# Altium schematic sheet MCU.SchDoc
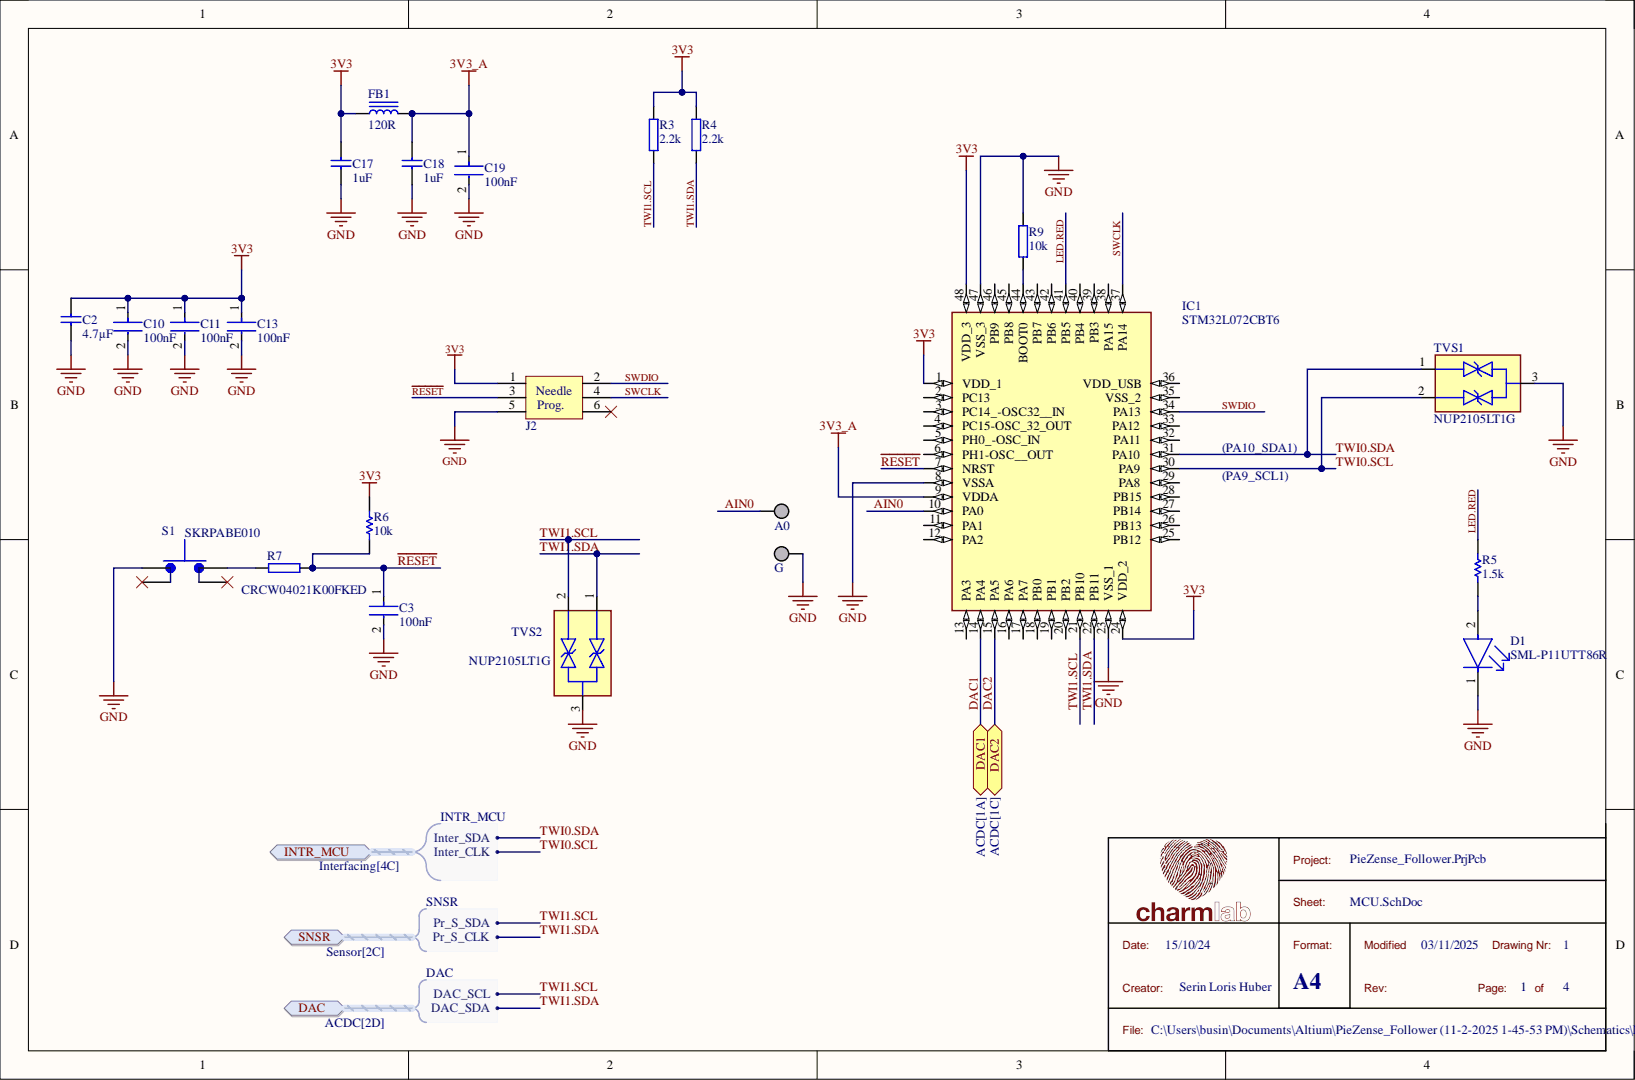
[diagram p0: MCU.SchDoc]

## Parts
A0  Test point  [TEST_POINT]  (value not drawn)  (no BOM)
C2  4.7µF  [CAP0603]
C3  100nF  [FP-GRM155-0_05-MFG]
C10  100nF  [FP-GRM155-0_05-MFG]
C11  100nF  [FP-GRM155-0_05-MFG]
C13  100nF  [FP-GRM155-0_05-MFG]
C17  1uF  [CAP0402]
C18  1uF  [CAP0402]
C19  100nF  [FP-GRM155-0_05-MFG]
D1  SML-P11UTT86R  [FP-SML-P1-MFG]
FB1  120R  [FB0402]
G  Test point  [TEST_POINT]  (value not drawn)  (no BOM)
IC1  STM32L072CBT6  [QFP50P900X900X160-48N]
J2  TC2030-MCP-NL  [6-Pin_Needle_Programmer]  (value not drawn)  (no BOM)
R3  2.2k  [RES0402]
R4  2.2k  [RES0402]
R5  1.5k  [RESC1005X40X25LL05T10]
R6  10k  [RESC1005X40X25ML05T10]
R7  CRCW04021K00FKED  [RES0402]
R9  10k  [RES0402]
S1  SKRPABE010  [SW_SKRPABE010]
TVS1  NUP2105LT1G  [SOT95P237]
TVS2  NUP2105LT1G  [SOT95P237]

## Ports
DAC, DAC1, DAC2, DAC.DAC_SCL, DAC.DAC_SDA, INTR_MCU, INTR_MCU.Inter_CLK, INTR_MCU.Inter_SDA, SNSR, SNSR.Pr_S_CLK, SNSR.Pr_S_SDA

## Nets
3V3: C2.1 C10.1 C11.1 C13.1 C17.2 FB1.1 IC1.1(VDD_1) IC1.24(VDD_2) IC1.48(VDD_3) J2.1 R3.2 R4.2 R6.2
3V3_A: C18.2 C19.1 FB1.2 IC1.9(VDDA)
GND: C2.2 C3.2 C10.2 C11.2 C13.2 C17.1 C18.1 C19.2 D1.1 G.1 IC1.8(VSSA) IC1.23(VSS_1) IC1.47(VSS_3) J2.5 R9.2 S1.1 TVS1.3 TVS2.3
AIN0: A0.1 IC1.10(PA0)
DAC1: IC1.14(PA4) ; port DAC1
DAC2: IC1.15(PA5) ; port DAC2
LED.RED: IC1.41(PB5) R5.2
~{RESET}: C3.1 IC1.7(NRST) J2.3 R6.1 R7.2
SWCLK: IC1.37(PA14) J2.4(Needle)
SWDIO: IC1.34(PA13) J2.2
TWI0.SCL: IC1.30(PA9) TVS1.2 ; port INTR_MCU
TWI0.SDA: IC1.31(PA10) TVS1.1 ; port INTR_MCU
TWI1.SCL: IC1.21(PB10) R3.1 TVS2.2 ; port DAC, port SNSR
TWI1.SDA: IC1.22(PB11) R4.1 TVS2.1 ; port DAC, port SNSR
NetD1_2: D1.2 R5.1
NetIC1_44: IC1.44(BOOT0) R9.1
NetR7_1: R7.1 S1.3

Not connected: IC1 2(PC13) 3(PC14_-OSC32__IN) 4(PC15-OSC_32_OUT) 5(PH0_-OSC_IN) 6(PH1-OSC__OUT) 11(PA1) 12(PA2) 13(PA3) 16(PA6) 17(PA7) 18(PB0) 19(PB1) 20(PB2) 25(PB12) 26(PB13) 27(PB14) 28(PB15) 29(PA8) 32(PA11) 33(PA12) 35(VSS_2) 36(VDD_USB) 38(PA15) 39(PB3) 40(PB4) 42(PB6) 43(PB7) 45(PB8) 46(PB9); J2 6; S1 2 4

## Library data of the parts
C2 = GRM188R6YA475KE15: CAP CER 4.7uF 35V X5R 0603; Manufacturer: Murata Electronics; Manufacturer Part Number: GRM188R6YA475KE15D; Part Number: GRT033C80J224KE01D; Capacitor Type: Ceramic; Multilayer: Yes; Negative Tolerance: 10%; Temperature Coefficient: X6S; Tolerance: ±10%; Voltage - Rated: 6.3V; Applications: Automotive; Capacitance: 4.7µF; Category: Ceramic Capacitors; Component Kind: Standard; ComponentLink1Description: Datasheet; ComponentLink1URL: https://search.murata.co.jp/Ceramy/image/img/A01X/G101/ENG/GRT033C80J224KE01-01.pdf; ComponentLink2Description: DigiKey Link; ComponentLink2URL: http://digikey.com/product-detail/en/murata-electronics/GRT033C80J224KE01D/490-12230-1-ND/5416931; ComponentLink3Description: https://datasheet.ciiva.com:443/pdfs/VipMasterIC/IC/MURE/MURE-S-A0004349797/MURE-S-A0004352734-1.pdf?src-supplier=IHS+Markit; ComponentLink3URL: https://datasheet.ciiva.com:443/pdfs/VipMasterIC/IC/MURE/MURE-S-A0004349797/MURE-S-A0004352734-1.pdf?src-supplier=IHS+Markit; ComponentLink4Description: https://datasheet.ciiva.com/46444/1769893-46444984.pdf?src-supplier=Element14; ComponentLink4URL: https://datasheet.ciiva.com/46444/1769893-46444984.pdf?src-supplier=Element14; ComponentLink5Description: https://datasheet.ciiva.com/46444/1769893-46444985.pdf?src-supplier=Element14; ComponentLink5URL: https://datasheet.ciiva.com/46444/1769893-46444985.pdf?src-supplier=Element14; ComponentLink6Description: https://datasheet.ciiva.com/14906/partnumbering-e-01-14906427.pdf?src-supplier=Digi-Key; ComponentLink6URL: https://datasheet.ciiva.com/14906/partnumbering-e-01-14906427.pdf?src-supplier=Digi-Key; ComponentLink7Description: https://datasheet.ciiva.com/14906/partnumbering-e-01-14906426.pdf?src-supplier=Digi-Key; ComponentLink7URL: https://datasheet.ciiva.com/14906/partnumbering-e-01-14906426.pdf?src-supplier=Digi-Key; ComponentLink8Description: https://datasheet.ciiva.com/14906/partnumbering-e-01-14906428.pdf?src-supplier=Digi-Key; ComponentLink8URL: https://datasheet.ciiva.com/14906/partnumbering-e-01-14906428.pdf?src-supplier=Digi-Key; Device Package: 0201 (0603 Metric); Dielectric Material: Ceramic; Features: Derating Recommended; Height: 0.8mm; JESD-609 Code: e3; LastUpdated: 02/09/2020 00:58:08; Length: 1.6mm; Lifecycle Status: Active; Max Operating Temperature: 85°C; Min Operating Temperature: -55°C; Minimum Order: 1; Mount: Surface Mount; Mounting Type: Surface Mount, MLCC; Number of Pins: 2; Operating Temperature: -55°C ~ 105°C; Package / Case: 0201 (0603 Metric); Package Shape: Rectangular; Package Style: SMTMeter; Packaging: TapeAndReel; Part Status: Active; PartId: 1513; Positive Tolerance: 10%; Price: 0.01; Pricing 1: 25=0.48200, 250=0.45200, 500=0.42400, 1000=0.40000, 2500=0.36100 (USD); Rated DC Voltage (URdc): 35V; Ratings: AEC-Q200; REACH SVHC: Yes; RoHS: Yes; RoHS Compliant: Yes; Series: GRT; Size / Dimension: 0.024" L x 0.012" W (0.60mm x 0.30mm); Size Code: 0603; Stock 1: 3100; Supplier 1: RSComponents; Supplier 2: Mouser; Supplier 3: Digikey; Supplier 4: Digikey; Supplier 5: Digikey; Supplier Part Number 1: 8151351; Supplier Part Number 2: 81-GRM188R6YA475KE5D; Supplier Part Number 3: 490-7205-1-ND; Supplier Part Number 4: 490-7205-6-ND; Supplier Part Number 5: 490-7205-2-ND; Temperature Characteristics Code: X5R; Terminal Finish: Nickel; Termination: Wraparound; Thickness (Max): 0.013" (0.33mm); Value: 4.7µF; Width: 0.8mm; Library Name: schuluka.SCHLIB
C3 C10 C11 C13 C19 = CMP-2008-04108-2: Chip Multilayer Ceramic Capacitors for General Purpose, 0402, 0.10uF, X5R, 15%, 10%, 50V; Capacitance: 100nF; Case Code (Imperial): 0402; Case Code (Metric): 1005; Case/Package: 0402; Component Kind: Standard; Depth: 0.5mm; Dielectric: X5R; Height: 0.5mm; Lead Free: Lead Free; Length: 1mm; Library Name: Altium Content Vault; Max Operating Temperature: 85°C; Min Operating Temperature: -55°C; Mount: Surface Mount; Packaging: Tape and Reel; REACH SVHC: No SVHC; Thickness: 0.022inch; Tolerance: 10%; Voltage: 50V; Voltage Rating: 50V; Voltage Rating (DC): 50V; Width: 0.02inch
C17 C18 = GRM155R61E105KA12J: CAP CER 1uF 25V X5R 0402; Manufacturer: Murata; Manufacturer Part Number: GRM033R61A105ME15D; Capacitor Type: Ceramic; Multilayer: Yes; Negative Tolerance: 10%; Tolerance: 20%; Voltage Rating: 10V; Capacitance: 1uF; Case Code (Imperial): 0201; Case Code (Metric): 0603; Case/Package: 0201; Category: Ceramic Capacitors; Component Kind: Standard; ComponentLink1Description: http://search.murata.co.jp/Ceramy/image/img/A01X/G101/ENG/GRM033R61A105ME15-01.pdf?src-supplier=Digi-Key; ComponentLink1URL: http://search.murata.co.jp/Ceramy/image/img/A01X/G101/ENG/GRM033R61A105ME15-01.pdf?src-supplier=Digi-Key; ComponentLink2Description: https://datasheet.ciiva.com:443/pdfs/VipMasterIC/IC/MURE/MURE-S-A0004362469/MURE-S-A0004364524-1.pdf?src-supplier=IHS+Markit; ComponentLink2URL: https://datasheet.ciiva.com:443/pdfs/VipMasterIC/IC/MURE/MURE-S-A0004362469/MURE-S-A0004364524-1.pdf?src-supplier=IHS+Markit; ComponentLink3Description: http://search.murata.co.jp/Ceramy/image/img/A01X/G101/ENG/GRM155R61E105KA12-01.pdf?src-supplier=Digi-Key; ComponentLink3URL: http://search.murata.co.jp/Ceramy/image/img/A01X/G101/ENG/GRM155R61E105KA12-01.pdf?src-supplier=Digi-Key; Dielectric: X5R; Dielectric Material: Ceramic; Height: 0.5mm; JESD-609 Code: e3; Length: 0.024inch; Max Operating Temperature: 85°C; Min Operating Temperature: -55°C; Mount: Surface Mount; Number of Pins: 2; Package Shape: Rectangular; Package Style: SMTMeter; Packaging: Tape and Reel; Positive Tolerance: 10%; Pricing 1: 1=0.18000, 10=0.08400, 50=0.08400, 100=0.03800, 1000=0.02100, 10000=0.01600 (USD); Rated DC Voltage (URdc): 25V; REACH SVHC: Yes; RoHS: Yes; RoHS Compliant: Yes; Size Code: 0402; Stock 1: 645001; Supplier 1: Mouser; Supplier Part Number 1: 81-GRM155R61E105KA2J; Temperature Characteristics Code: X5R; Terminal Finish: Nickel; Termination: Wraparound; Thickness: 0.015inch; Voltage Rating (DC): 10V; Width: 0.012inch; Library Name: schuluka.SCHLIB
D1 = CMP-08952-000008-1: LED RED CLEAR 1006 SMD; Manufacturer: Rohm; Forward Current: 1mA; Forward Voltage: 1.8V; Led Orientation: Top View; Luminous Intensity: 2.5mcd; Power Dissipation: 50mW; Reverse Voltage: 5V; Wavelength: 621nm; Automotive: No; Category: Diode; Colour: Red; Component Kind: Standard; ComponentLink2Description: Datasheet; ComponentLink2URL: https://www.rohm.com/datasheet/SML-P11UT(R)/sml-p11-e; Device Class L1: Optoelectronics; Device Class L2: LEDs; Device Class L3: unset; Digikey Description: LED RED CLEAR 1006 SMD; Height: 0.25mm; Lead Free: Yes; Library Name: Altium Content Vault; Manufacturer Part Number: SML-P11UTT86R; Mouser Description: Led, Picoled, Red, 621 Nm, 1.8 V, 1 Ma, 2.5 Mcd; Octopart: https://octopart.com/sml-p11utt86r-rohm-39058751; Package: SML-P1; RoHS: TRUE; Temperature: -40°C to +85°C; Temperature Range High: +85°C; Temperature Range Low: -40°C
FB1 = MPZ1005S121CT000: Ferrite Chip, 1 Function(s), 1.2A, 2 Pin(s); Manufacturer: Murata; Manufacturer Part Number: BLM03PX121SN1D; DC Resistance-Max: 90mR; Max Frequency: 100MHz; Number of Functions: 1; Case Code: 0402; Category: Ferrite Beads and Chips; Component Kind: Standard; ComponentLink1Description: https://datasheet.ciiva.com:443/pdfs/VipMasterIC/IC/TDKC/TDKC-S-A0008032406/TDKC-S-A0008032406-1.pdf?src-supplier=IHS+Markit; ComponentLink1URL: https://datasheet.ciiva.com:443/pdfs/VipMasterIC/IC/TDKC/TDKC-S-A0008032406/TDKC-S-A0008032406-1.pdf?src-supplier=IHS+Markit; ComponentLink2Description: https://datasheet.ciiva.com/46381/1795297-46381675.pdf?src-supplier=Element14; ComponentLink2URL: https://datasheet.ciiva.com/46381/1795297-46381675.pdf?src-supplier=Element14; ComponentLink3Description: https://datasheet.ciiva.com/46634/beads-commercial-power-mpz-en-46634460.pdf?src-supplier=Digi-Key; ComponentLink3URL: https://datasheet.ciiva.com/46634/beads-commercial-power-mpz-en-46634460.pdf?src-supplier=Digi-Key; ComponentLink4Description: https://datasheet.ciiva.com/46634/beads-commercial-power-mpz-en-46634687.pdf?src-supplier=Digi-Key; ComponentLink4URL: https://datasheet.ciiva.com/46634/beads-commercial-power-mpz-en-46634687.pdf?src-supplier=Digi-Key; ComponentLink5Description: https://datasheet.ciiva.com/48682/beads-commercial-power-mpz-en-48682574.pdf?src-supplier=Avnet; ComponentLink5URL: https://datasheet.ciiva.com/48682/beads-commercial-power-mpz-en-48682574.pdf?src-supplier=Avnet; Construction: Chip Bead; Current Rating: 1.2A; Filter Type: FERRITE CHIP; Height: 0.5mm; Impedance: 120R; JESD-609 Code: e3; Length: 1mm; Material: Ferrite; Max Operating Temperature: 85°C; Min Frequency: 100MHz; Min Operating Temperature: -40°C; Mount: Surface Mount; Output Impedance: 120R; Packaging: Tape and Reel; Pricing 1: 1=0.10000, 10=0.05800, 50=0.05800, 100=0.03400, 1000=0.02800, 10000=0.02600 (USD); REACH SVHC: Yes; RoHS: Yes; RoHS Compliant: Yes; Stock 1: 116962; Supplier 1: Mouser; Supplier Part Number 1: 810-MPZ1005S121CT000; Terminal Finish: Nickel; Width: 0.5mm; Library Name: schuluka.SCHLIB
IC1 = STM32L072CBT6: ARMÂ® CortexÂ®-M0+ STM32L0 Microcontroller IC 32-Bit Single-Core 32MHz 128KB (128K x 8) FLASH 48-LQFP (7x7); MF: STMicroelectronics; Check_prices: https://www.snapeda.com/parts/STM32L072CBT6/STMicroelectronics/view-part/?ref=eda; Component Kind: Standard; Library Name: STM32L072CBT6.SchLib; MP: STM32L072CBT6; Package: LQFP-48 STMicroelectronics; SnapEDA_Link: https://www.snapeda.com/parts/STM32L072CBT6/STMicroelectronics/view-part/?ref=snap
J2 = 6-Pin_Needle_Programmer: CABLE, TAG CONNECT, WITH OUT LEG, FOR IC; Lead Free: Lead Free; Number of Pins: 6; Package Quantity: 1; Packaging: Bulk; REACH SVHC: No SVHC; Supplier 1: Digi-Key; Supplier Part Number 1: TC2030-MCP-NLTC-ND; Library Name: huberser.SchLib
R3 R4 = CRCW04022K20JNED: RES SMD 2.2k OHM  0.063W 0402; Manufacturer: Panasonic Electronic Components; Manufacturer Part Number: ERJ-1GNJ334C; Part Number: ERJ-1GNF5110C; Package Height: 0.35mm; Package Length: 1mm; Package Width: 0.5mm; Power (Watts): 0.05W, 1/20W; Rated Power Dissipation (P): 63mW; Rated Temperature: 70°C; Reference Standard: AEC-Q200; Resistance: 2.2k Ohms; Temperature Coefficient: ±200ppm/°C; Tolerance: ±1%; Working Voltage: 50V; Category: Chip SMD Resistors; Component Kind: Standard; ComponentLink1Description: Datasheet; ComponentLink1URL: https://industrial.panasonic.com/cdbs/www-data/pdf/RDA0000/AOA0000C304.pdf; ComponentLink2Description: DigiKey Link; ComponentLink2URL: http://digikey.com/product-detail/en/panasonic-electronic-components/ERJ-1GNF5110C/P123054CT-ND/8343047; ComponentLink3Description: http://www.onlinecomponents.com/datasheet/erj-1gnj334c.aspx?p=44467782&src-supplier=Onlinecomponents.com; ComponentLink3URL: http://www.onlinecomponents.com/datasheet/erj-1gnj334c.aspx?p=44467782&src-supplier=Onlinecomponents.com; ComponentLink4Description: https://b2b-api.panasonic.eu/file_stream/pids/fileversion/1242?src-supplier=Digi-Key; ComponentLink4URL: https://b2b-api.panasonic.eu/file_stream/pids/fileversion/1242?src-supplier=Digi-Key; ComponentLink5Description: http://industrial.panasonic.com/www-cgi/jvcr13pz.cgi?E+PZ+3+AOA0002+ERJ1GNJ334C+7+WW?src-supplier=Digi-Key; ComponentLink5URL: http://industrial.panasonic.com/www-cgi/jvcr13pz.cgi?E+PZ+3+AOA0002+ERJ1GNJ334C+7+WW?src-supplier=Digi-Key; ComponentLink6Description: http://industrial.panasonic.com/www-cgi/jvcr13pz.cgi?E+PZ+3+AOA0002+ERJ1GNJ120C+7+WW?src-supplier=Mouser; ComponentLink6URL: http://industrial.panasonic.com/www-cgi/jvcr13pz.cgi?E+PZ+3+AOA0002+ERJ1GNJ120C+7+WW?src-supplier=Mouser; ComponentLink7Description: https://datasheet.ciiva.com:443/pdfs/VipMasterIC/IC/VISH/VISH-S-A0007266705/VISH-S-A0007266705-1.pdf?src-supplier=IHS+Markit; ComponentLink7URL: https://datasheet.ciiva.com:443/pdfs/VipMasterIC/IC/VISH/VISH-S-A0007266705/VISH-S-A0007266705-1.pdf?src-supplier=IHS+Markit; ComponentLink8Description: https://datasheet.ciiva.com/2461/dcrcwe3-2461974.pdf?src-supplier=Digi-Key; ComponentLink8URL: https://datasheet.ciiva.com/2461/dcrcwe3-2461974.pdf?src-supplier=Digi-Key; ComponentLink9Description: https://datasheet.ciiva.com/2461/dcrcwe3-2461975.pdf?src-supplier=Digi-Key; ComponentLink9URL: https://datasheet.ciiva.com/2461/dcrcwe3-2461975.pdf?src-supplier=Digi-Key; ComponentLink10Description: https://datasheet.ciiva.com/2461/dcrcwe3-2461976.pdf?src-supplier=Digi-Key; ComponentLink10URL: https://datasheet.ciiva.com/2461/dcrcwe3-2461976.pdf?src-supplier=Digi-Key; ComponentLink11Description: https://datasheet.ciiva.com/7743/dcrcwe3-7743416.pdf?src-supplier=Element14; ComponentLink11URL: https://datasheet.ciiva.com/7743/dcrcwe3-7743416.pdf?src-supplier=Element14; ComponentLink12Description: https://datasheet.ciiva.com/16771/70201119-16771096.pdf?src-supplier=Allied+Electronics; ComponentLink12URL: https://datasheet.ciiva.com/16771/70201119-16771096.pdf?src-supplier=Allied+Electronics; ComponentLink13Description: https://datasheet.ciiva.com/7743/dcrcwe3-7743417.pdf?src-supplier=Element14; ComponentLink13URL: https://datasheet.ciiva.com/7743/dcrcwe3-7743417.pdf?src-supplier=Element14; ComponentLink14Description: https://datasheet.ciiva.com/16761/70201119-16761947.pdf?src-supplier=Allied+Electronics; ComponentLink14URL: https://datasheet.ciiva.com/16761/70201119-16761947.pdf?src-supplier=Allied+Electronics; ComponentLink15Description: https://datasheet.ciiva.com/3255/dcrcwe3-3255964.pdf?src-supplier=Avnet; ComponentLink15URL: https://datasheet.ciiva.com/3255/dcrcwe3-3255964.pdf?src-supplier=Avnet; ComponentLink16Description: https://datasheet.ciiva.com/3255/dcrcwe3-3255965.pdf?src-supplier=Avnet; ComponentLink16URL: https://datasheet.ciiva.com/3255/dcrcwe3-3255965.pdf?src-supplier=Avnet; ComponentLink17Description: https://datasheet.ciiva.com/7743/dcrcwe3-7743566.pdf?src-supplier=Element14; ComponentLink17URL: https://datasheet.ciiva.com/7743/dcrcwe3-7743566.pdf?src-supplier=Element14; ComponentLink18Description: https://datasheet.ciiva.com/2462/dcrcwe3-2462041.pdf?src-supplier=Digi-Key; ComponentLink18URL: https://datasheet.ciiva.com/2462/dcrcwe3-2462041.pdf?src-supplier=Digi-Key; ComponentLink19Description: https://datasheet.ciiva.com/2462/dcrcwe3-2462042.pdf?src-supplier=Digi-Key; ComponentLink19URL: https://datasheet.ciiva.com/2462/dcrcwe3-2462042.pdf?src-supplier=Digi-Key; ComponentLink20Description: https://datasheet.ciiva.com/2462/dcrcwe3-2462040.pdf?src-supplier=Digi-Key; ComponentLink20URL: https://datasheet.ciiva.com/2462/dcrcwe3-2462040.pdf?src-supplier=Digi-Key; ComponentLink21Description: https://datasheet.ciiva.com/16760/70201119-16760139.pdf?src-supplier=Allied+Electronics; ComponentLink21URL: https://datasheet.ciiva.com/16760/70201119-16760139.pdf?src-supplier=Allied+Electronics; ComponentLink22Description: https://datasheet.ciiva.com/5542/dcrcwe3-109170-5542006.pdf?src-supplier=Mouser; ComponentLink22URL: https://datasheet.ciiva.com/5542/dcrcwe3-109170-5542006.pdf?src-supplier=Mouser; ComponentLink23Description: https://datasheet.ciiva.com/3256/dcrcwe3-3256343.pdf?src-supplier=Avnet; ComponentLink23URL: https://datasheet.ciiva.com/3256/dcrcwe3-3256343.pdf?src-supplier=Avnet; ComponentLink24Description: https://datasheet.ciiva.com/16436/dcrcwe3-16436154.pdf?src-supplier=Future+Electronics; ComponentLink24URL: https://datasheet.ciiva.com/16436/dcrcwe3-16436154.pdf?src-supplier=Future+Electronics; ComponentLink25Description: https://datasheet.ciiva.com/3256/dcrcwe3-3256342.pdf?src-supplier=Avnet; ComponentLink25URL: https://datasheet.ciiva.com/3256/dcrcwe3-3256342.pdf?src-supplier=Avnet; Composition: Thick Film; Construction: Chip; Device Package: 0201 (0603 Metric); Features: Automotive AEC-Q200; Height - Seated (Max): 0.010" (0.26mm); JESD-609 Code: e3; LastUpdated: 02/09/2020 01:06:20; Lifecycle Status: Active; Max Operating Temperature: 155°C; Min Operating Temperature: -55°C; Minimum Order: 1; Mount: Surface Mount; Number of Pins: 2; Number of Terminations: 2; Operating Temperature: -55°C ~ 125°C; Package / Case: 0201 (0603 Metric); Package Shape: Rectangular; Package Style: SMTMeter; Packaging: TapeAndReel; Part Status: Active; PartId: 1262; Price: 0.01; Pricing 1: 1=0.10000, 10=0.03500, 50=0.03500, 100=0.01500, 1000=0.00700, 2500=0.00600, 5000=0.00500, 10000=0.00400 (USD); Pricing 2: 1=0.10000, 10=0.03200, 50=0.03200, 100=0.01300, 1000=0.00600, 10000=0.00400 (USD); Ratings: AEC-Q200; REACH SVHC: Yes; Resistor Type: FIXED RESISTOR; RoHS: Yes; RoHS Compliant: Yes; Series: ERJ; Size / Dimension: 0.024" L x 0.012" W (0.60mm x 0.30mm); Size Code: 0402; Stock 1: 20779; Stock 2: 32600; Supplier 1: Mouser; Supplier Device Package: 0201; Supplier Part Number 1: 71-CRCW0402J-2.2K-E3; Technology: METAL GLAZE/THICK FILM; Terminal Finish: Nickel; Termination: Wraparound; Value: 2.2k; Library Name: schuluka.SCHLIB
R5 = CMP-2002-03049-1: Manufacturer: Vishay Dale; Part Number: CRCW04021K50FKEDHP; Component Kind: Standard; Library Name: Altium Content Vault
R6 = CMP-2002-03043-1: Manufacturer: Vishay Dale; Part Number: CRCW040210K0FKEDHP; Component Kind: Standard; Library Name: Altium Content Vault
R7 = CRCW04021K00FKED: RES SMD 1k OHM  0.063W 0402; Manufacturer: Panasonic Electronic Components; Manufacturer Part Number: ERJ-1GNJ334C; Part Number: ERJ-1GNF5110C; Package Height: 0.35mm; Package Length: 1mm; Package Width: 0.5mm; Power (Watts): 0.05W, 1/20W; Rated Power Dissipation (P): 63mW; Rated Temperature: 70°C; Reference Standard: AEC-Q200; Resistance: 1k Ohms; Temperature Coefficient: ±200ppm/°C; Tolerance: ±1%; Working Voltage: 50V; Category: Chip SMD Resistors; Component Kind: Standard; ComponentLink1Description: Datasheet; ComponentLink1URL: https://industrial.panasonic.com/cdbs/www-data/pdf/RDA0000/AOA0000C304.pdf; ComponentLink2Description: DigiKey Link; ComponentLink2URL: http://digikey.com/product-detail/en/panasonic-electronic-components/ERJ-1GNF5110C/P123054CT-ND/8343047; ComponentLink3Description: http://www.onlinecomponents.com/datasheet/erj-1gnj334c.aspx?p=44467782&src-supplier=Onlinecomponents.com; ComponentLink3URL: http://www.onlinecomponents.com/datasheet/erj-1gnj334c.aspx?p=44467782&src-supplier=Onlinecomponents.com; ComponentLink4Description: https://b2b-api.panasonic.eu/file_stream/pids/fileversion/1242?src-supplier=Digi-Key; ComponentLink4URL: https://b2b-api.panasonic.eu/file_stream/pids/fileversion/1242?src-supplier=Digi-Key; ComponentLink5Description: http://industrial.panasonic.com/www-cgi/jvcr13pz.cgi?E+PZ+3+AOA0002+ERJ1GNJ334C+7+WW?src-supplier=Digi-Key; ComponentLink5URL: http://industrial.panasonic.com/www-cgi/jvcr13pz.cgi?E+PZ+3+AOA0002+ERJ1GNJ334C+7+WW?src-supplier=Digi-Key; ComponentLink6Description: http://industrial.panasonic.com/www-cgi/jvcr13pz.cgi?E+PZ+3+AOA0002+ERJ1GNJ120C+7+WW?src-supplier=Mouser; ComponentLink6URL: http://industrial.panasonic.com/www-cgi/jvcr13pz.cgi?E+PZ+3+AOA0002+ERJ1GNJ120C+7+WW?src-supplier=Mouser; ComponentLink7Description: https://datasheet.ciiva.com:443/pdfs/VipMasterIC/IC/VISH/VISH-S-A0007266705/VISH-S-A0007266705-1.pdf?src-supplier=IHS+Markit; ComponentLink7URL: https://datasheet.ciiva.com:443/pdfs/VipMasterIC/IC/VISH/VISH-S-A0007266705/VISH-S-A0007266705-1.pdf?src-supplier=IHS+Markit; ComponentLink8Description: https://datasheet.ciiva.com/11808/0900766b80d98824-11808638.pdf?src-supplier=RSComponents; ComponentLink8URL: https://datasheet.ciiva.com/11808/0900766b80d98824-11808638.pdf?src-supplier=RSComponents; ComponentLink9Description: https://datasheet.ciiva.com/2463/dcrcwe3-2463030.pdf?src-supplier=Digi-Key; ComponentLink9URL: https://datasheet.ciiva.com/2463/dcrcwe3-2463030.pdf?src-supplier=Digi-Key; ComponentLink10Description: https://datasheet.ciiva.com/46385/1697337-46385499.pdf?src-supplier=Element14; ComponentLink10URL: https://datasheet.ciiva.com/46385/1697337-46385499.pdf?src-supplier=Element14; ComponentLink11Description: https://datasheet.ciiva.com/3256/dcrcwe3-3256140.pdf?src-supplier=Avnet; ComponentLink11URL: https://datasheet.ciiva.com/3256/dcrcwe3-3256140.pdf?src-supplier=Avnet; ComponentLink12Description: https://datasheet.ciiva.com/16721/70201119-16721452.pdf?src-supplier=Allied+Electronics; ComponentLink12URL: https://datasheet.ciiva.com/16721/70201119-16721452.pdf?src-supplier=Allied+Electronics; ComponentLink13Description: https://datasheet.ciiva.com/2463/dcrcwe3-2463028.pdf?src-supplier=Digi-Key; ComponentLink13URL: https://datasheet.ciiva.com/2463/dcrcwe3-2463028.pdf?src-supplier=Digi-Key; ComponentLink14Description: https://datasheet.ciiva.com/2463/dcrcwe3-2463029.pdf?src-supplier=Digi-Key; ComponentLink14URL: https://datasheet.ciiva.com/2463/dcrcwe3-2463029.pdf?src-supplier=Digi-Key; ComponentLink15Description: https://datasheet.ciiva.com/7743/dcrcwe3-7743477.pdf?src-supplier=Element14; ComponentLink15URL: https://datasheet.ciiva.com/7743/dcrcwe3-7743477.pdf?src-supplier=Element14; ComponentLink16Description: https://datasheet.ciiva.com/26316/fld-crcw-e3-data-en-26316646.pdf?src-supplier=Distrelec; ComponentLink16URL: https://datasheet.ciiva.com/26316/fld-crcw-e3-data-en-26316646.pdf?src-supplier=Distrelec; ComponentLink17Description: https://datasheet.ciiva.com/3256/dcrcwe3-3256139.pdf?src-supplier=Avnet; ComponentLink17URL: https://datasheet.ciiva.com/3256/dcrcwe3-3256139.pdf?src-supplier=Avnet; ComponentLink18Description: https://datasheet.ciiva.com/16287/dcrcwe3-16287807.pdf?src-supplier=Future+Electronics; ComponentLink18URL: https://datasheet.ciiva.com/16287/dcrcwe3-16287807.pdf?src-supplier=Future+Electronics; Composition: Thick Film; Construction: Chip; Device Package: 0201 (0603 Metric); Features: Automotive AEC-Q200; Height - Seated (Max): 0.010" (0.26mm); JESD-609 Code: e3; LastUpdated: 02/09/2020 01:06:20; Lifecycle Status: Active; Max Operating Temperature: 155°C; Min Operating Temperature: -55°C; Minimum Order: 1; Mount: Surface Mount; Number of Pins: 2; Number of Terminations: 2; Operating Temperature: -55°C ~ 125°C; Package / Case: 0201 (0603 Metric); Package Shape: Rectangular; Package Style: SMTMeter; Packaging: TapeAndReel; Part Status: Active; PartId: 1262; Price: 0.01; Pricing 1: 50=0.06200, 500=0.05600, 1000=0.05100, 2000=0.04600, 4000=0.04000 (USD); Pricing 2: 1=0.10000, 10=0.03200, 50=0.03200, 100=0.01300, 1000=0.00600, 10000=0.00400 (USD); Ratings: AEC-Q200; REACH SVHC: Yes; Resistor Type: FIXED RESISTOR; RoHS: Yes; RoHS Compliant: Yes; Series: ERJ; Size / Dimension: 0.024" L x 0.012" W (0.60mm x 0.30mm); Size Code: 0402; Stock 1: 9350; Stock 2: 32600; Supplier 1: RSComponents; Supplier 2: Mouser; Supplier 3: Digi-Key; Supplier 4: Digi-Key; Supplier 5: Digi-Key; Supplier Device Package: 0201; Supplier Part Number 1: 6788872; Supplier Part Number 2: 71-CRCW0402-1.0K-E3; Supplier Part Number 3: 541-1.00KLCT-ND; Supplier Part Number 4: 541-1.00KLDKR-ND; Supplier Part Number 5: 541-1.00KLTR-ND; Technology: METAL GLAZE/THICK FILM; Terminal Finish: Nickel; Termination: Wraparound; Value: 1k; Library Name: schuluka.SCHLIB
R9 = RC0402FR-0710KL: Fixed Resistor, Metal Glaze/thick Film, 0.0625W, 10000ohm, 50V, 1% +/-Tol, 100ppm/Cel, Surface Mount, 0402; Manufacturer: Panasonic Electronic Components; Part Number: ERJ-1GNF5110C; Additional Feature: LASER TRIMMABLE; Package Height: 0.35mm; Package Length: 1mm; Package Width: 0.5mm; Power (Watts): 0.05W, 1/20W; Rated Power Dissipation (P): 62.5mW; Rated Temperature: 70°C; Resistance: 10k Ohms; Temperature Coefficient: ±200ppm/°C; Category: Chip SMD Resistors; Component Kind: Standard; ComponentLink1Description: Datasheet; ComponentLink1URL: https://industrial.panasonic.com/cdbs/www-data/pdf/RDA0000/AOA0000C304.pdf; ComponentLink2Description: DigiKey Link; ComponentLink2URL: http://digikey.com/product-detail/en/panasonic-electronic-components/ERJ-1GNF5110C/P123054CT-ND/8343047; ComponentLink3Description: https://datasheet.ciiva.com:443/pdfs/VipMasterIC/IC/YAGO/YAGO-S-A0008145938/YAGO-S-A0008145938-1.pdf?src-supplier=IHS+Markit; ComponentLink3URL: https://datasheet.ciiva.com:443/pdfs/VipMasterIC/IC/YAGO/YAGO-S-A0008145938/YAGO-S-A0008145938-1.pdf?src-supplier=IHS+Markit; ComponentLink4Description: https://datasheet.ciiva.com/7660/38568-7660787.pdf?src-supplier=Element14; ComponentLink4URL: https://datasheet.ciiva.com/7660/38568-7660787.pdf?src-supplier=Element14; ComponentLink5Description: https://datasheet.ciiva.com/7849/1686128-7849814.pdf?src-supplier=Element14; ComponentLink5URL: https://datasheet.ciiva.com/7849/1686128-7849814.pdf?src-supplier=Element14; ComponentLink6Description: https://datasheet.ciiva.com/7983/1018594-7983486.pdf?src-supplier=Element14; ComponentLink6URL: https://datasheet.ciiva.com/7983/1018594-7983486.pdf?src-supplier=Element14; ComponentLink7Description: https://datasheet.ciiva.com/22747/1018594-22747512.pdf?src-supplier=Element14; ComponentLink7URL: https://datasheet.ciiva.com/22747/1018594-22747512.pdf?src-supplier=Element14; ComponentLink8Description: https://datasheet.ciiva.com/12667/pyu-rc0402-51-rohs-l-6-r-12667067.pdf?src-supplier=Mouser; ComponentLink8URL: https://datasheet.ciiva.com/12667/pyu-rc0402-51-rohs-l-6-r-12667067.pdf?src-supplier=Mouser; ComponentLink9Description: https://datasheet.ciiva.com/7849/1784430-7849813.pdf?src-supplier=Element14; ComponentLink9URL: https://datasheet.ciiva.com/7849/1784430-7849813.pdf?src-supplier=Element14; ComponentLink10Description: https://datasheet.ciiva.com/7849/1784430-7849815.pdf?src-supplier=Element14; ComponentLink10URL: https://datasheet.ciiva.com/7849/1784430-7849815.pdf?src-supplier=Element14; Composition: Thick Film; Construction: Chip; Device Package: 0201 (0603 Metric); Features: Automotive AEC-Q200; Height - Seated (Max): 0.010" (0.26mm); JESD-609 Code: e3; LastUpdated: 02/09/2020 01:06:20; Lifecycle Status: Active; Manufacturer Part Number: RC0402FR-0710KL; Max Operating Temperature: 155°C; Min Operating Temperature: -55°C; Minimum Order: 1; Mount: Surface Mount; Number of Pins: 2; Number of Terminations: 2; Operating Temperature: -55°C ~ 125°C; Package / Case: 0201 (0603 Metric); Package Shape: Rectangular; Package Style: SMTMeter; Packaging: TapeAndReel; Part Status: Active; PartId: 1262; Price: 0.01; Pricing 1: 100=0.01000, 1000=0.01000, 2500=0.00900, 5000=0.00800, 10000=0.00800 (GBP); Ratings: AEC-Q200; REACH SVHC: Yes; Resistor Type: FIXED RESISTOR; RoHS: Yes; RoHS Compliant: Yes; Series: ERJ; Size / Dimension: 0.024" L x 0.012" W (0.60mm x 0.30mm); Size Code: 0402; Stock 1: 42700; Supplier 1: RSComponents; Supplier Device Package: 0201; Supplier Part Number 1: 1987644; Technology: METAL GLAZE/THICK FILM; Terminal Finish: Nickel; Termination: Wraparound; Tolerance: ±1%; Value: 10k; Working Voltage: 50V; Library Name: schuluka.SCHLIB
S1 = CMP-010-000003-2: Switch Tactile N.O. SPST Button J-Bend 0.05A 16VDC 1.57N SMD Automotive T/R; MF: ALPS; Check_prices: https://www.snapeda.com/parts/SKRPABE010/ALPS/view-part/?ref=eda; Component Kind: Standard; Component Type: Standard; Design Item ID: SKRPABE010; MP: SKRPABE010; Package: None; Pin Count: 4; SnapEDA_Link: https://www.snapeda.com/parts/SKRPABE010/ALPS/view-part/?ref=snap; Source: SKRPABE010.SchLib; Library Name: CHARM_PieZense
TVS1 TVS2 = NUP2105LT1G: Dual Bi-Directional TVS Diode 350W 3-Pin SOT-23 | ON Semiconductor NUP2105LT1G; Component Kind: Standard; Library Name: schuluka.SCHLIB
A0 G = Test point: Library Name: schuluka.SCHLIB
